# Altium schematic sheet MCU.SchDoc(MCU)
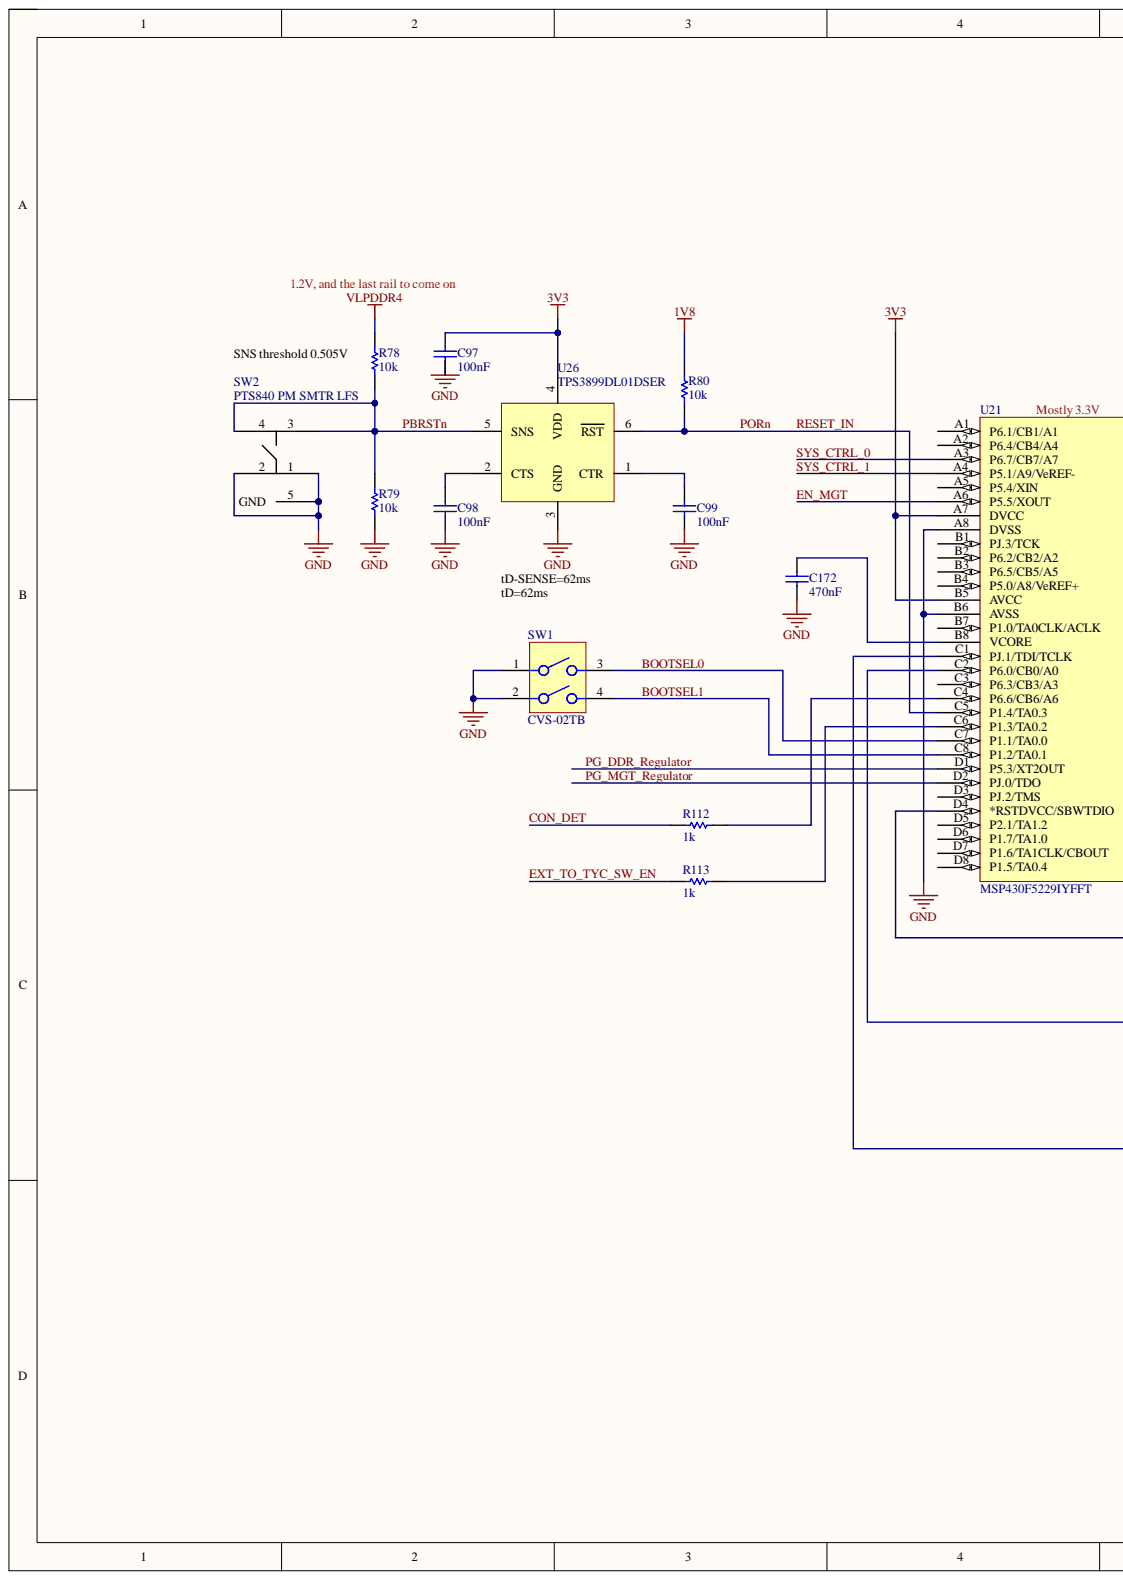
[diagram p0: MCU.SchDoc, left]
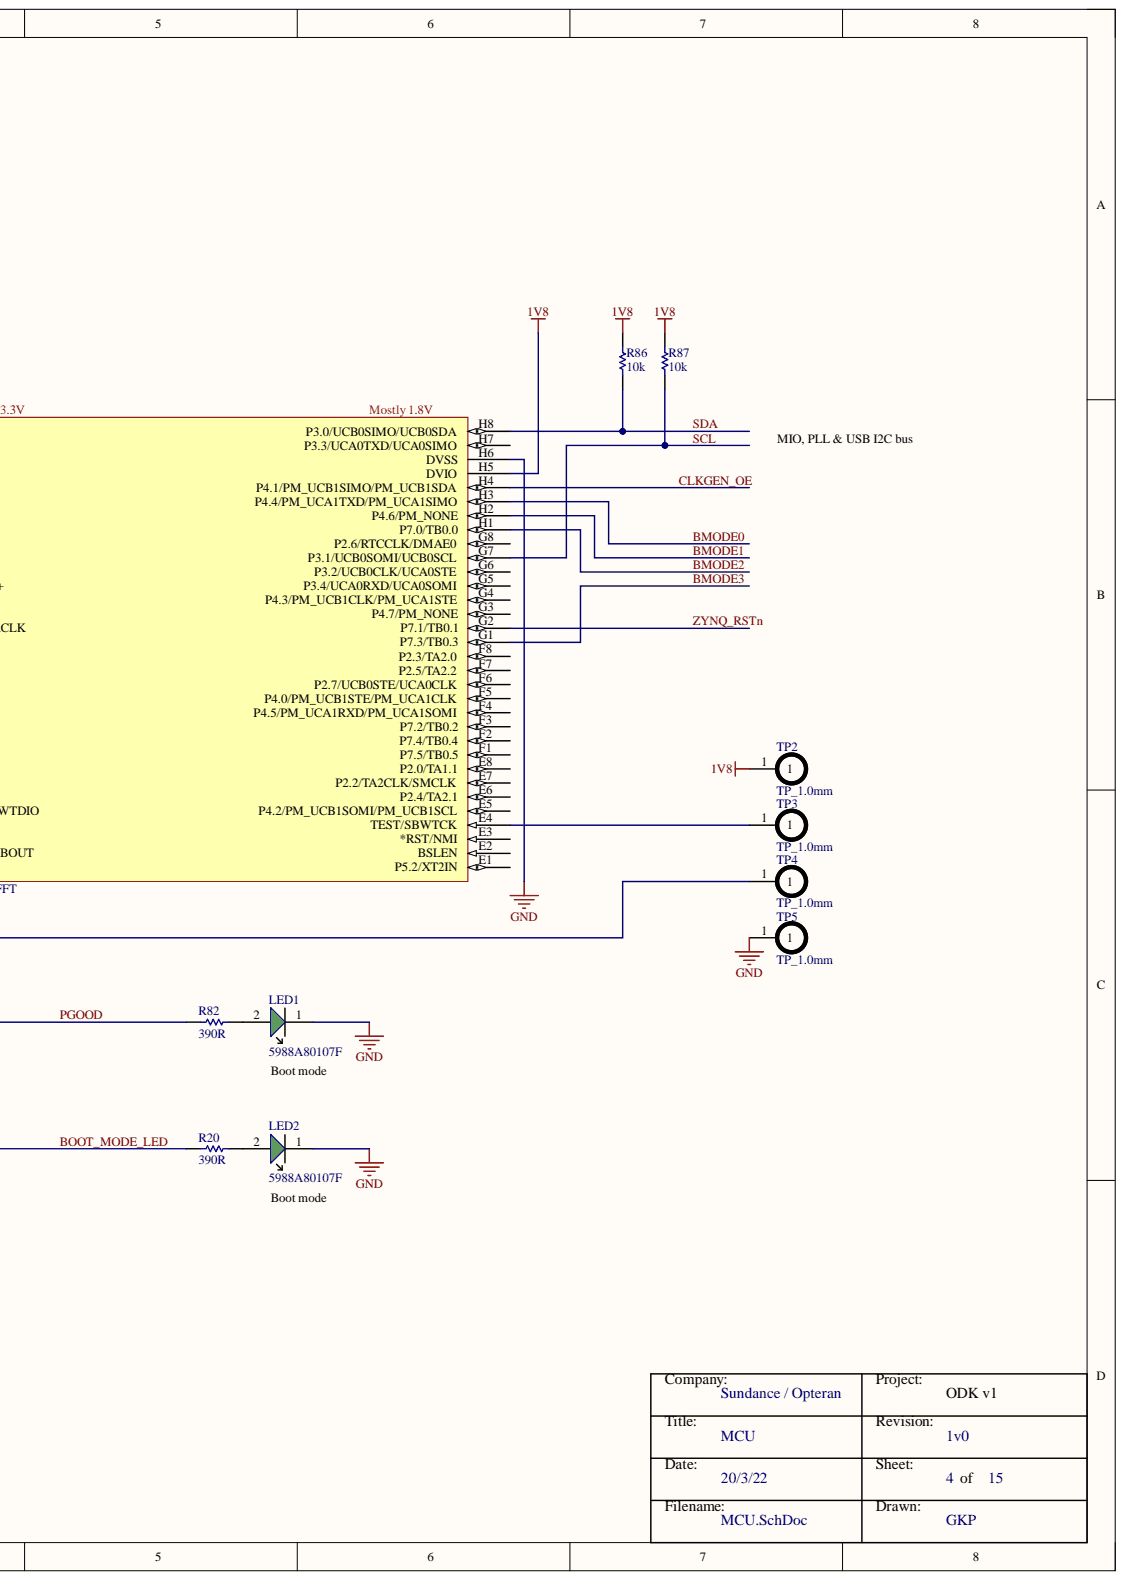
[diagram p1: MCU.SchDoc, right]

## Parts
C97  100nF  [0201panERJ]
C98  100nF  [0201panERJ]
C99  100nF  [0201panERJ]
C172  470nF  [0201panERJ]
LED1  5988A80107F  [LED0402 - GRN]
LED2  5988A80107F  [LED0402 - GRN]
R20  390R  [RES0201]
R78  10k  [RES0201]
R79  10k  [RES0201]
R80  10k  [RES0201]
R82  390R  [RES0201]
R86  10k  [RES0201]
R87  10k  [RES0201]
R112  1k  [RES0201]
R113  1k  [RES0201]
SW1  CVS-02TB  [DIP SW CVS-02B]
SW2  PTS840 PM SMTR LFS  [PTS840]
TP2  TP_1.0mm  [TPR_1.0MM]  (no BOM)
TP3  TP_1.0mm  [TPR_1.0MM]  (no BOM)
TP4  TP_1.0mm  [TPR_1.0MM]  (no BOM)
TP5  TP_1.0mm  [TPR_1.0MM]  (no BOM)
U21  MSP430F5229IYFFT  [YFF64_TEX]
U26  TPS3899DL01DSER  [TI WSON 6 DSE]

## Nets
1V8: R80.1 R86.1 R87.1 TP2.1 U21.H5(DVIO)
3V3: C97.2 U21.A7(DVCC) U21.B5(AVCC) U26.4(VDD)
GND: C97.1 C98.1 C99.1 C172.1 LED1.1 LED2.1 R79.2 SW1.1 SW1.2 SW2.1 SW2.2 SW2.5(GND) TP5.1 U21.A8(DVSS) U21.B6(AVSS) U21.H6(DVSS) U26.3(GND)
VLPDDR4: R78.1
BMODE0: U21.H3(P4.4/PM_UCA1TXD/PM_UCA1SIMO)
BMODE1: U21.H2(P4.6/PM_NONE)
BMODE2: U21.H1(P7.0/TB0.0)
BMODE3: U21.G1(P7.3/TB0.3)
BOOT_MODE_LED: R20.1 U21.C1(PJ.1/TDI/TCLK)
BOOTSEL0: SW1.3 U21.C7(P1.1/TA0.0)
BOOTSEL1: SW1.4 U21.C8(P1.2/TA0.1)
CLKGEN_OE: U21.H4(P4.1/PM_UCB1SIMO/PM_UCB1SDA)
CON_DET: R112.1
EN_MGT: U21.A6(P5.5/XOUT)
EXT_TO_TYC_SW_EN: R113.1
PBRSTn: R78.2 R79.1 SW2.3 SW2.4 U26.5(SNS)
PG_DDR_Regulator: U21.D1(P5.3/XT2OUT)
PG_MGT_Regulator: U21.D2(PJ.0/TDO)
PGOOD: R82.1 U21.C2(P6.0/CB0/A0)
PORn: R80.2 U21.C5(P1.4/TA0.3) U26.6(RST)
SCL: R87.2 U21.G7(P3.1/UCB0SOMI/UCB0SCL)
SDA: R86.2 U21.H8(P3.0/UCB0SIMO/UCB0SDA)
SYS_CTRL_0: U21.A3(P6.7/CB7/A7)
SYS_CTRL_1: U21.A4(P5.1/A9/VeREF-)
ZYNQ_RSTn: U21.G2(P7.1/TB0.1)
NetC98_2: C98.2 U26.2(CTS)
NetC99_2: C99.2 U26.1(CTR)
NetC172_2: C172.2 U21.B8(VCORE)
NetLED1_2: LED1.2 R82.2
NetLED2_2: LED2.2 R20.2
NetR112_2: R112.2 U21.C4(P6.6/CB6/A6)
NetR113_2: R113.2 U21.C6(P1.3/TA0.2)
NetTP3_1: TP3.1 U21.E4(TEST/SBWTCK)
NetTP4_1: TP4.1 U21.D4(*RSTDVCC/SBWTDIO)

Not connected: U21 A1(P6.1/CB1/A1) A2(P6.4/CB4/A4) A5(P5.4/XIN) B1(PJ.3/TCK) B2(P6.2/CB2/A2) B3(P6.5/CB5/A5) B4(P5.0/A8/VeREF+) B7(P1.0/TA0CLK/ACLK) C3(P6.3/CB3/A3) D3(PJ.2/TMS) D5(P2.1/TA1.2) D6(P1.7/TA1.0) D7(P1.6/TA1CLK/CBOUT) D8(P1.5/TA0.4) E1(P5.2/XT2IN) E2(BSLEN) E3(*RST/NMI) E5(P4.2/PM_UCB1SOMI/PM_UCB1SCL) E6(P2.4/TA2.1) E7(P2.2/TA2CLK/SMCLK) E8(P2.0/TA1.1) F1(P7.5/TB0.5) F2(P7.4/TB0.4) F3(P7.2/TB0.2) F4(P4.5/PM_UCA1RXD/PM_UCA1SOMI) F5(P4.0/PM_UCB1STE/PM_UCA1CLK) F6(P2.7/UCB0STE/UCA0CLK) F7(P2.5/TA2.2) F8(P2.3/TA2.0) G3(P4.7/PM_NONE) G4(P4.3/PM_UCB1CLK/PM_UCA1STE) G5(P3.4/UCA0RXD/UCA0SOMI) G6(P3.2/UCB0CLK/UCA0STE) G8(P2.6/RTCCLK/DMAE0) H7(P3.3/UCA0TXD/UCA0SIMO)

## Library data of the parts
C97-C99 = Cap0201: 100nF 0201 16V X5R; Case-EIA: 0402; Component Kind: Standard; Library Name: Schematic Components.SchLib; Max Thickness: 0.6 mm; Technology: SMT
C172 = Cap0201: 470nF 0201 16V X5R; Case-EIA: 0402; Component Kind: Standard; Library Name: Schematic Components.SchLib; Max Thickness: 0.6 mm; Technology: SMT
LED1 LED2 = LED 0402 GRN: LED, Green, SMD, 0402 [1005 Metric], 5 mA, 2.5 V, 528 nm; Manufacturer 1: DIALIGHT; Component Kind: Standard; Library Name: Schematic Components.SchLib; Manufacturer Part Number 1: 5988A80107F; Supplier 1: Digikey; Supplier Part Number 1: 350-4451-2-ND
R20 R82 = Res0201: 390R 0201 1/16W 1%; Value: ?k; Component Kind: Standard; Library Name: Schematic Components.SchLib
R78-R80 R86 R87 = Res0201: 10k 0201 1/16W 1%; Value: ?k; Component Kind: Standard; Library Name: Schematic Components.SchLib
R112 R113 = Res0201: 1k 0201 1/16W 1%; Value: ?k; Component Kind: Standard; Library Name: Schematic Components.SchLib
SW1 = DIP SW CVS-02TB: Dip Switch SPST 2 Position Surface Mount Slide (Standard) Actuator 100mA 6VDC; Manufacturer 1: Nidec Copal Electronics; Component Kind: Standard; Library Name: Schematic Components.SchLib; Manufacturer Part Number 1: CVS-02TB; Supplier 1: Digi-Key; Supplier Part Number 1: 563-1193-2-ND
SW2 = PTS840: Tactile Switch SPST-NO Side Actuated Surface Mount, Right Angle; Manufacturer 1: C&K; Component Kind: Standard; Library Name: Schematic Components.SchLib; Manufacturer Part Number 1: PTS840 PM SMTR LFS; Supplier 1: Digi-Key; Supplier Part Number 1: CKN10511CT-ND
TP2-TP5 = TP_1.0mm: Test pad 1.0mm; Library Name: Connectors pads.SchLib
U21 = MSP430F5229IYFFT: CPUXV2 series Microcontroller IC 16-Bit 25MHz 128KB (128K x 8) FLASH 64-DSBGA; Manufacturer 1: Texas Instruments; Component Kind: Standard; Library Name: Schematic Components.SchLib; Manufacturer Part Number 1: MSP430F5229IYFFT; Supplier 1: Digi-Key; Supplier Part Number 1: 296-MSP430F5229IYFFTTR-ND
U26 = TPS3899DL01DSER: Supervisor Open Drain or Open Collector 1 Channel 6-WSON (1.5x1.5).Active low output. 0.505V threshold.; Manufacturer 1: Texas Instruments; Component Kind: Standard; Library Name: Schematic Components.SchLib; Manufacturer Part Number 1: TPS3899DL01DSER; Supplier 1: Digi-Key; Supplier Part Number 1: 296-TPS3899DL01DSERTR-ND
